# Altium schematic sheet MCU_AND_PW2.SchDoc
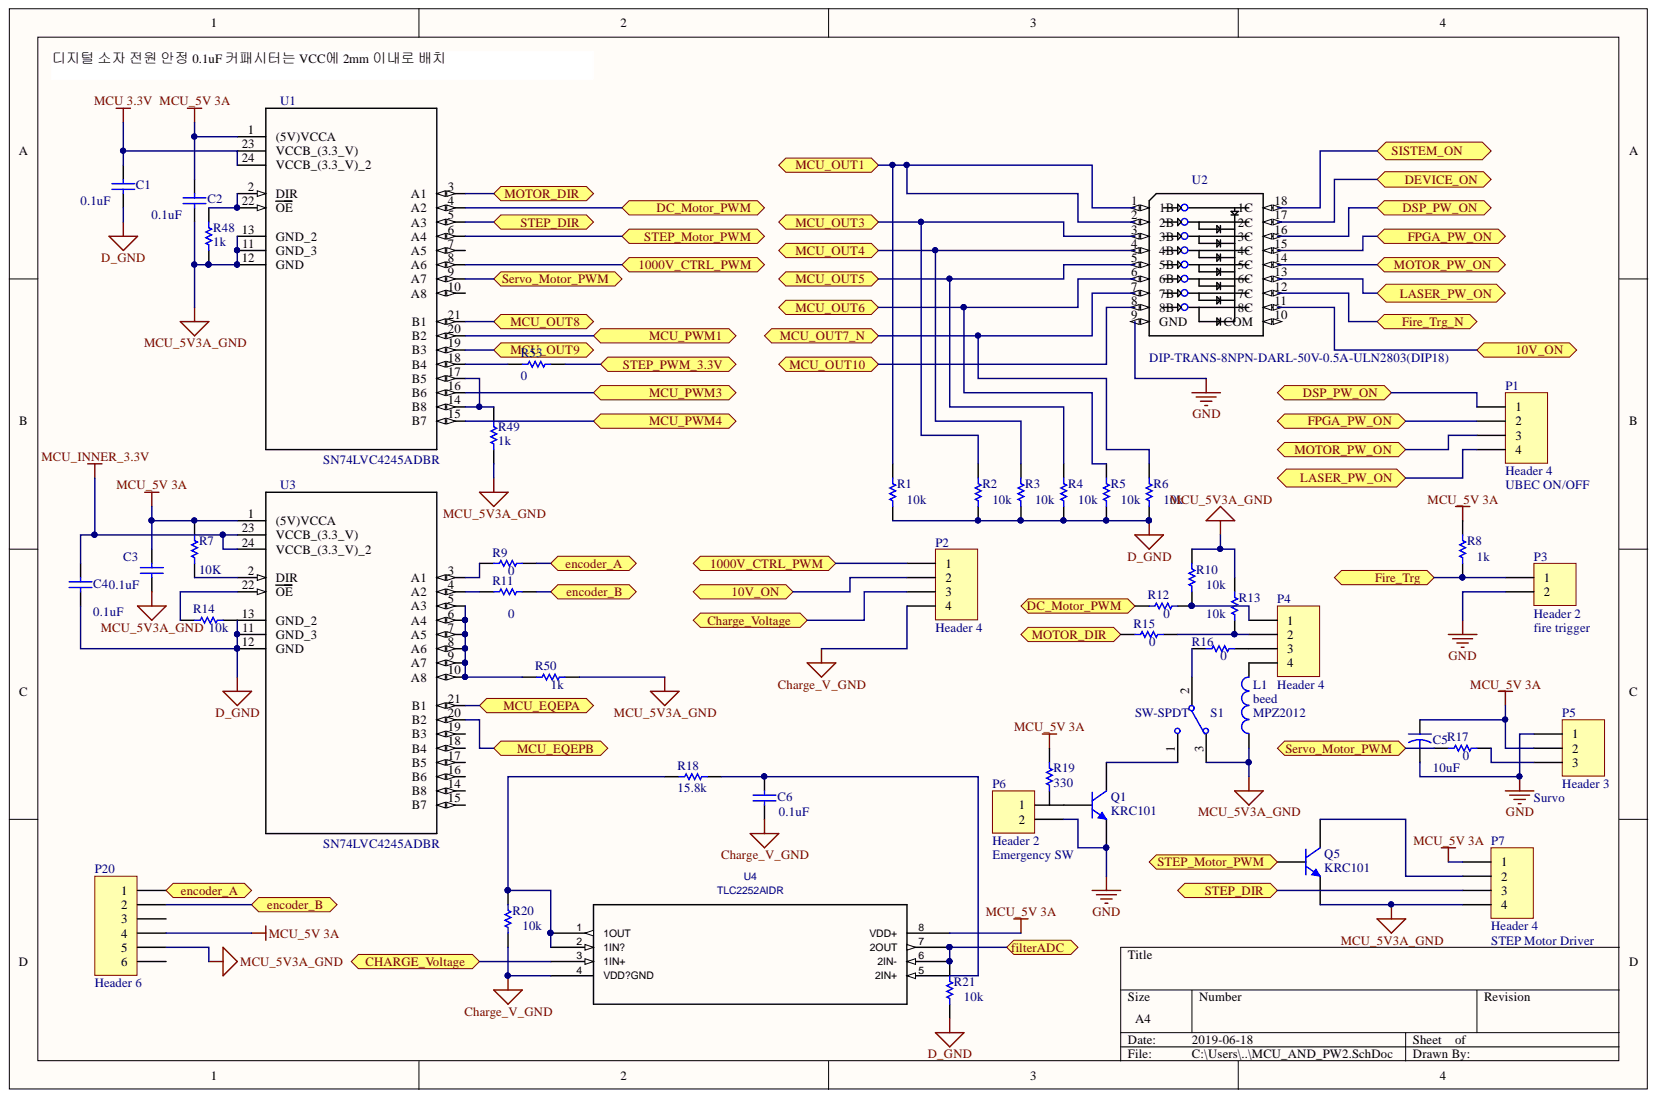
[diagram p0: MCU_AND_PW2.SchDoc]

## Parts
C1  value 0.1uF  [RAD-0.3]  (hidden comment: Cap)
C2  value 0.1uF  [RAD-0.3]  (hidden comment: Cap)
C3  value 0.1uF  [RAD-0.3]  (hidden comment: Cap)
C4  value 0.1uF  [RAD-0.3]  (hidden comment: Cap)
C5  value 10uF  [CAPR5-4X5]  (hidden comment: Cap2)
C6  value 0.1uF  [RAD-0.3]  (hidden comment: Cap)
L1  beed  value MPZ2012  [0402-A]
P1  Header 4  [HDR1X4]
P2  Header 4  [HDR1X4]
P3  Header 2  [HDR1X2]
P4  Header 4  [HDR1X4]
P5  Header 3  [HDR1X3]
P6  Header 2  [HDR1X2]
P7  Header 4  [HDR1X4]
P20  Header 6  [HDR1X6]
Q1  KRC101  [TO-226-AA]
Q5  KRC101  [TO-226-AA]
R1  value 10k  [AXIAL-0.3]  (hidden comment: Res1)
R2  value 10k  [AXIAL-0.3]  (hidden comment: Res1)
R3  value 10k  [AXIAL-0.3]  (hidden comment: Res1)
R4  value 10k  [AXIAL-0.3]  (hidden comment: Res1)
R5  value 10k  [AXIAL-0.3]  (hidden comment: Res1)
R6  value 10k  [AXIAL-0.3]  (hidden comment: Res1)
R7  value 10K  [AXIAL-0.3]  (hidden comment: Res1)
R8  value 1k  [AXIAL-0.3]  (hidden comment: Res1)
R9  value 0  [AXIAL-0.3]  (hidden comment: Res1)
R10  value 10k  [AXIAL-0.3]  (hidden comment: Res1)
R11  value 0  [AXIAL-0.3]  (hidden comment: Res1)
R12  value 0  [AXIAL-0.3]  (hidden comment: Res1)
R13  value 10k  [AXIAL-0.3]  (hidden comment: Res1)
R14  value 10k  [AXIAL-0.3]  (hidden comment: Res1)
R15  value 0  [AXIAL-0.3]  (hidden comment: Res1)
R16  value 0  [AXIAL-0.3]  (hidden comment: Res1)
R17  value 0  [AXIAL-0.3]  (hidden comment: Res1)
R18  value 15.8k  [AXIAL-0.3]  (hidden comment: Res1)
R19  value 330  [AXIAL-0.3]  (hidden comment: Res1)
R20  value 10k  [AXIAL-0.3]  (hidden comment: Res1)
R21  value 10k  [AXIAL-0.3]  (hidden comment: Res1)
R48  value 1k  [AXIAL-0.3]  (hidden comment: Res1)
R49  value 1k  [AXIAL-0.3]  (hidden comment: Res1)
R50  value 1k  [AXIAL-0.3]  (hidden comment: Res1)
R53  value 0  [AXIAL-0.3]  (hidden comment: Res1)
S1  SW-SPDT  [TL36WW15050]
U1  SN74LVC4245ADBR  [*SOP65P780X200-24N]
U2  DIP-TRANS-8NPN-DARL-50V-0.5A-ULN2803(DIP18)  [*DIP18-2.54-22.8X7.62MM]
U3  SN74LVC4245ADBR  [*SOP65P780X200-24N]
U4  TLC2252AIDR  [D8]

## Ports
10V_ON, 1000V_CTRL_PWM, CHARGE_VOLTAGE, DC_MOTOR_PWM, DEVICE_ON, DSP_PW_ON, ENCODER_A, ENCODER_B, FILTERADC, FIRE_TRG, FIRE_TRG_N, FPGA_PW_ON, LASER_PW_ON, MCU_EQEPA, MCU_EQEPB, MCU_OUT1, MCU_OUT3, MCU_OUT4, MCU_OUT5, MCU_OUT6, MCU_OUT7_N, MCU_OUT8, MCU_OUT9, MCU_OUT10, MCU_PWM1, MCU_PWM3, MCU_PWM4, MOTOR_DIR, MOTOR_PW_ON, SERVO_MOTOR_PWM, SISTEM_ON, STEP_DIR, STEP_MOTOR_PWM, STEP_PWM_3.3V

## Nets
CHARGE_V_GND: C6.1 P2.4 R20.1 U4.4(VDD?GND)
D_GND: C1.1 C4.2 R1.1 R2.1 R3.1 R4.1 R5.1 R6.1 R21.1 U3.11(GND_3) U3.12(GND) U3.13(GND_2)
GND: C5.2 P3.2 P5.1 P6.2 Q1.3 U2.9(GND)
MCU 3.3V: C1.2 U1.24(VCCB_(3.3_V)_2)
MCU_5V3A_GND: C2.1 C3.1 L1.1 P7.4 P20.5 Q5.3 R10.2 R13.2 R48.1 R49.1 R50.2 S1.3 U1.11(GND_3) U1.12(GND) U1.13(GND_2)
MCU_5V 3A: C2.2 C3.2 C5.1 P5.2 P7.1 P20.4 R7.2 R8.2 R19.2 U1.1((5V)VCCA) U3.1((5V)VCCA) U4.8(VDD+)
MCU_INNER_3.3V: C4.1 U3.23(VCCB_(3.3_V)) U3.24(VCCB_(3.3_V)_2)
10V_ON: P2.2 U2.11(8C) ; port 10V_ON
1000V_CTRL_PWM: P2.1 U1.8(A6) ; port 1000V_CTRL_PWM
DEVICE_ON: U2.17(2C) ; port DEVICE_ON
DSP_PW_ON: P1.1 U2.16(3C) ; port DSP_PW_ON
FPGA_PW_ON: P1.2 U2.15(4C) ; port FPGA_PW_ON
LASER_PW_ON: P1.4 U2.13(6C) ; port LASER_PW_ON
MCU_EQEPA: U3.21(B1) ; port MCU_EQEPA
MCU_EQEPB: U3.20(B2) ; port MCU_EQEPB
MCU_OUT1: R1.2 U2.1(1B) U2.2(2B) ; port MCU_OUT1
MCU_OUT3: R2.2 U2.3(3B) ; port MCU_OUT3
MCU_OUT4: R3.2 U2.4(4B) ; port MCU_OUT4
MCU_OUT5: R4.2 U2.5(5B) ; port MCU_OUT5
MCU_OUT6: R5.2 U2.6(6B) ; port MCU_OUT6
MCU_OUT7_N: R6.2 U2.7(7B) ; port MCU_OUT7_N
MCU_OUT8: U1.21(B1) ; port MCU_OUT8
MCU_OUT9: U1.19(B3) ; port MCU_OUT9
MCU_OUT10: U2.8(8B) ; port MCU_OUT10
MCU_PWM1: U1.20(B2) ; port MCU_PWM1
MCU_PWM3: U1.16(B6) ; port MCU_PWM3
MCU_PWM4: U1.15(B7) ; port MCU_PWM4
MOTOR_DIR: R15.1 U1.3(A1) ; port MOTOR_DIR
MOTOR_PW_ON: P1.3 U2.14(5C) ; port MOTOR_PW_ON
SISTEM_ON: U2.18(1C) ; port SISTEM_ON
STEP_DIR: P7.3 U1.5(A3) ; port STEP_DIR
STEP_PWM_3.3V: R53.1 ; port STEP_PWM_3.3V
NetC6_2: C6.2 R18.1 U4.5(2IN+)
NetL1_2: L1.2 P4.4
NetP2_3: P2.3 U4.3(1IN+) ; port CHARGE_VOLTAGE
NetP3_1: P3.1 R8.1 ; port FIRE_TRG
NetP4_1: P4.1 R10.1 R12.2
NetP4_2: P4.2 R13.1 R15.2
NetP4_3: P4.3 R16.2
NetP5_3: P5.3 R17.2
NetP6_1: P6.1 Q1.2
NetP7_2: P7.2 Q5.1
NetP20_1: P20.1 R9.2 ; port ENCODER_A
NetP20_2: P20.2 R11.2 ; port ENCODER_B
NetQ1_1: Q1.1 S1.1
NetQ5_2: Q5.2 U1.6(A4) ; port STEP_MOTOR_PWM
NetR7_1: R7.1 U3.2(DIR)
NetR9_1: R9.1 U3.3(A1)
NetR11_1: R11.1 U3.4(A2)
NetR12_1: R12.1 U1.4(A2) ; port DC_MOTOR_PWM
NetR14_1: R14.1 U3.22(OE)
NetR16_1: R16.1 S1.2
NetR17_1: R17.1 U1.9(A7) ; port SERVO_MOTOR_PWM
NetR18_2: R18.2 R20.2 U4.1(1OUT) U4.2(1IN?)
NetR21_2: R21.2 U4.6(2IN-) U4.7(2OUT) ; port FILTERADC
NetR48_2: R48.2 U1.2(DIR) U1.22(OE)
NetR49_2: R49.2 U1.14(B8) U1.17(B5)
NetR50_1: R50.1 U3.5(A3) U3.6(A4) U3.7(A5) U3.8(A6) U3.9(A7) U3.10(A8)
NetR53_2: R53.2 U1.18(B4)
NetU2_12: U2.12(7C) ; port FIRE_TRG_N

Not connected: P20 3 6; R14 2; R19 1; U1 7(A5) 10(A8) 23(VCCB_(3.3_V)); U2 10(COM); U3 14(B8) 15(B7) 16(B6) 17(B5) 18(B4) 19(B3)

## Library data of the parts
C1-C4 C6 = Cap: Capacitor; Component Kind: Standard; LatestRevisionDate: 17-Jul-2002; LatestRevisionNote: Re-released for DXP Platform.; Library Name: Miscellaneous Devices.IntLib; PackageDescription: Radial Cap, Thru-Hole; 2 Leads; 0.3 in Pin Spacing; PackageReference: RAD-0.3; Published: 8-Jun-2000; Signal Integrity: Cap; Simulation: CAP; Publisher: Altium Limited
C5 = Cap2: Capacitor; Component Kind: Standard; Library Name: Miscellaneous Devices.IntLib; PackageDescription: Capacitor; 2 Leads; PackageReference: CAPR5-4X5; Published: 23-Sep-2002; Signal Integrity: Cap; Simulation: CAP; Publisher: Altium Limited
L1 = Inductor: Code_IEC: 1005; Code_JEITA: 0402; Component Kind: Standard; Description: Inductor; LatestRevisionDate: 29-May-2009; LatestRevisionNote: IPC-7351 Footprint Added.; Library Name: Miscellaneous Devices.IntLib; PackageDescription: Chip Inductor; PackageReference: 0402-A; Published: 8-Jun-2000; Signal Integrity: Inductor; Simulation: INDUCTOR; Publisher: Altium Limited
P1 P2 P4 P7 = Header 4: Header, 4-Pin; Component Kind: Standard; LatestRevisionDate: 17-Jul-2002; LatestRevisionNote: Re-released for DXP Platform.; Library Name: Miscellaneous Connectors.IntLib; Signal Integrity: Connector; Publisher: Altium Limited
P3 P6 = Header 2: Header, 2-Pin; Component Kind: Standard; LatestRevisionDate: 17-Jul-2002; LatestRevisionNote: Re-released for DXP Platform.; Library Name: Miscellaneous Connectors.IntLib; Signal Integrity: Connector; Publisher: Altium Limited
P5 = Header 3: Header, 3-Pin; Component Kind: Standard; LatestRevisionDate: 17-Jul-2002; LatestRevisionNote: Re-released for DXP Platform.; Library Name: Miscellaneous Connectors.IntLib; Signal Integrity: Connector; Publisher: Altium Limited
P20 = Header 6: Header, 6-Pin; Component Kind: Standard; LatestRevisionDate: 17-Jul-2002; LatestRevisionNote: Re-released for DXP Platform.; Library Name: Miscellaneous Connectors.IntLib; Signal Integrity: Connector; Publisher: Altium Limited
Q1 Q5 = NPN: NPN Bipolar Transistor; Code_JEDEC: TO-226-AA; Component Kind: Standard; LatestRevisionDate: 17-Jul-2002; LatestRevisionNote: Re-released for DXP Platform.; Library Name: Miscellaneous Devices.IntLib; PackageDescription: TO, Flat Index; 3 In-Line, Axial Leads; Body Dia. 4.8mm; Leads 0.55 x 0.51 mm (max); PackageReference: TO-226-AA; Published: 8-Jun-2000; Signal Integrity: NPN; Simulation: NPN; Publisher: Altium Limited
R1-R21 R48-R50 R53 = Res1: Resistor; Component Kind: Standard; LatestRevisionDate: 17-Jul-2002; LatestRevisionNote: Re-released for DXP Platform.; Library Name: Miscellaneous Devices.IntLib; PackageDescription: Axial Device, Thru-Hole; 2 Leads; 0.3 in Pin Spacing; PackageReference: AXIAL-0.3; Published: 8-Jun-2000; Signal Integrity: Res; Simulation: RESISTOR; Publisher: Altium Limited
S1 = SW-SPDT: SPDT Subminiature Toggle Switch, Right Angle Mounting, Vertical Actuation; Component Kind: Standard; ComponentLink1Description: Manufacturer Link; ComponentLink1URL: http://www.apem.com; DatasheetVersion: 05-Dec-2000; LatestRevisionDate: 17-Dec-2003; LatestRevisionNote: Schematic revised, PCB Footprint replaced.; Library Name: Miscellaneous Devices.IntLib; PackageDescription: Switch; 4 Leads; PackageReference: TL36WW15050; Published: 24-Mar-1999; Publisher: Altium Limited
U1 U3 = SN74LVC4245ADBR: Octal Bus Transceiver And 3.3-V To 5-V Shifter With 3-State Outputs 24-SSOP -40 to 85; MF: Texas Instruments; Availability: Unavailable; Component Kind: Standard; MP: SN74LVC4245ADBR; Package: SSOP-24 Texas Instruments; Price: None; SnapEDA_Link: https://www.snapeda.com/parts/SN74LVC4245ADBR/Texas%20Instruments/view-part/?ref=snap
  U1: Library Name: SN74LVC4245ADBR.SchLib
  U3: Library Name: SN74LVC4245ADBR.IntLib
U2 = DIP-TRANS-8NPN-DARL-50V-0.5A-ULN2803(DIP18): Trans Darlington NPN 50V 0.5A 18-Pin DIP; MF: Toshiba Semiconductor; MP: ULN2803APG,CN; Availability: Unavailable; Component Kind: Standard; Library Name: DIP-TRANS-8NPN-DARL-50V-0.5A-ULN2803_DIP18_.SchLib; Package: DIP-18 Toshiba; Price: None; SnapEDA_Link: https://www.snapeda.com/parts/ULN2803APG%2CCN/Toshiba%20Semiconductor%20and%20Storage/view-part/?ref=snap
U4 = TLC2252AIDR: Manufacturer: Texas Instruments; Built_By: EMA_UL_Team; C: Copyright (C) 2015 Accelerated Designs. All rights reserved; Component Kind: Standard; Library Name: TLC2252AIDR.SchLib; Manufacturer_Part_Number: TLC2252AIDR; Value: TLC2252AIDR; Vendor: Texas Instruments
